# Altium schematic sheet Power.SchDoc(Power) (continued)

## Library data of the parts
C1 C7 = C0603C104K5RACAUTO: Multilayer Ceramic Capacitors MLCC - SMD/SMT 50V 0.1uF X7R 0603 10% AEC-Q200; Manufacturer: Yageo Group; Dissipation Factor: 2.5%; Temperature Coefficient: 30ppm/°C; Value: 100nF; Case Code (Imperial): 0603; Case Code (Metric): 1608; Case/Package: 0603; Category: Ceramic Capacitors; China RoHS: Compliant; Component Kind: Standard; ComponentLink1Description: https://datasheet.octopart.com:443/C0603C104K5RACAUTO-KEMET-datasheet-178087915.pdf?src-supplier=Farnell; ComponentLink1URL: https://datasheet.octopart.com:443/C0603C104K5RACAUTO-KEMET-datasheet-178087915.pdf?src-supplier=Farnell; ComponentLink2Description: https://datasheet.octopart.com:443/C0603C104K5RACAUTO-KEMET-datasheet-140480346.pdf?src-supplier=Farnell; ComponentLink2URL: https://datasheet.octopart.com:443/C0603C104K5RACAUTO-KEMET-datasheet-140480346.pdf?src-supplier=Farnell; ComponentLink3Description: https://datasheet.octopart.com:443/C0603C104K5RACAUTO-KEMET-datasheet-138896278.pdf?src-supplier=Farnell; ComponentLink3URL: https://datasheet.octopart.com:443/C0603C104K5RACAUTO-KEMET-datasheet-138896278.pdf?src-supplier=Farnell; ComponentLink4Description: https://datasheet.octopart.com:443/C0603C104K5RACAUTO-KEMET-datasheet-101575653.pdf?src-supplier=Farnell; ComponentLink4URL: https://datasheet.octopart.com:443/C0603C104K5RACAUTO-KEMET-datasheet-101575653.pdf?src-supplier=Farnell; ComponentLink5Description: https://datasheet.octopart.com:443/C0603C104K5RACAUTO-KEMET-datasheet-169773475.pdf?src-supplier=Farnell; ComponentLink5URL: https://datasheet.octopart.com:443/C0603C104K5RACAUTO-KEMET-datasheet-169773475.pdf?src-supplier=Farnell; ComponentLink6Description: https://datasheet.octopart.com:443/C0603C104K5RAC-AUTO-Kemet-datasheet-11517047.pdf?src-supplier=Farnell; ComponentLink6URL: https://datasheet.octopart.com:443/C0603C104K5RAC-AUTO-Kemet-datasheet-11517047.pdf?src-supplier=Farnell; ComponentLink7Description: https://datasheet.octopart.com:443/C0603C104K5RACAUTO-KEMET-datasheet-137357764.pdf?src-supplier=Upverter; ComponentLink7URL: https://datasheet.octopart.com:443/C0603C104K5RACAUTO-KEMET-datasheet-137357764.pdf?src-supplier=Upverter; ComponentLink8Description: https://datasheet.octopart.com:443/C0603C104K5RACAUTO-Kemet-datasheet-17277841.pdf?src-supplier=Newark; ComponentLink8URL: https://datasheet.octopart.com:443/C0603C104K5RACAUTO-Kemet-datasheet-17277841.pdf?src-supplier=Newark; ComponentLink9Description: https://datasheet.octopart.com:443/C0603C104K5RAC-AUTO-Kemet-datasheet-10850653.pdf?src-supplier=Newark; ComponentLink9URL: https://datasheet.octopart.com:443/C0603C104K5RAC-AUTO-Kemet-datasheet-10850653.pdf?src-supplier=Newark; ComponentLink10Description: https://datasheet.octopart.com:443/C0603C104K5RACAUTO-KEMET-datasheet-176289396.pdf?src-supplier=JRH+Electronics; ComponentLink10URL: https://datasheet.octopart.com:443/C0603C104K5RACAUTO-KEMET-datasheet-176289396.pdf?src-supplier=JRH+Electronics; ComponentLink11Description: https://datasheet.octopart.com:443/C0603C104K5RACAUTO-KEMET-datasheet-153410967.pdf?src-supplier=Electro+Sonic; ComponentLink11URL: https://datasheet.octopart.com:443/C0603C104K5RACAUTO-KEMET-datasheet-153410967.pdf?src-supplier=Electro+Sonic; ComponentLink12Description: https://datasheet.octopart.com:443/C0603C104K5RACAUTO-KEMET-datasheet-142166320.pdf?src-supplier=Electro+Sonic; ComponentLink12URL: https://datasheet.octopart.com:443/C0603C104K5RACAUTO-KEMET-datasheet-142166320.pdf?src-supplier=Electro+Sonic; ComponentLink13Description: https://datasheet.octopart.com:443/C0603C104K5RACAUTO-Kemet-datasheet-66076775.pdf?src-supplier=element14+APAC; ComponentLink13URL: https://datasheet.octopart.com:443/C0603C104K5RACAUTO-Kemet-datasheet-66076775.pdf?src-supplier=element14+APAC; ComponentLink14Description: https://datasheet.octopart.com:443/C0603C104K5RACAUTO-Kemet-datasheet-66204749.pdf?src-supplier=element14+APAC; ComponentLink14URL: https://datasheet.octopart.com:443/C0603C104K5RACAUTO-Kemet-datasheet-66204749.pdf?src-supplier=element14+APAC; ComponentLink15Description: https://datasheet.octopart.com:443/C0603C104K5RACAUTO-Kemet-datasheet-66534724.pdf?src-supplier=element14+APAC; ComponentLink15URL: https://datasheet.octopart.com:443/C0603C104K5RACAUTO-Kemet-datasheet-66534724.pdf?src-supplier=element14+APAC; ComponentLink16Description: https://datasheet.octopart.com:443/C0603C104K5RACAUTO-KEMET-datasheet-83968784.pdf?src-supplier=TME; ComponentLink16URL: https://datasheet.octopart.com:443/C0603C104K5RACAUTO-KEMET-datasheet-83968784.pdf?src-supplier=TME; ComponentLink17Description: https://datasheet.octopart.com:443/C0603C104K5RACAUTO-KEMET-datasheet-83186888.pdf?src-supplier=TME; ComponentLink17URL: https://datasheet.octopart.com:443/C0603C104K5RACAUTO-KEMET-datasheet-83186888.pdf?src-supplier=TME; ComponentLink18Description: https://datasheet.octopart.com/C0603C104K5RACAUTO-KEMET-datasheet-84169754.pdf; ComponentLink18URL: https://datasheet.octopart.com/C0603C104K5RACAUTO-KEMET-datasheet-84169754.pdf; ComponentLink19Description: https://datasheet.octopart.com:443/C0603C104K5RACAUTO-KEMET-datasheet-189767472.pdf?src-supplier=ODG+(Origin+Data+Global); ComponentLink19URL: https://datasheet.octopart.com:443/C0603C104K5RACAUTO-KEMET-datasheet-189767472.pdf?src-supplier=ODG+(Origin+Data+Global); ComponentLink20Description: http://sigma.octopart.com:80/conflict_mineral_statement/Yageo-company-3.pdf?src-supplier=Compliance+Statements; ComponentLink20URL: http://sigma.octopart.com:80/conflict_mineral_statement/Yageo-company-3.pdf?src-supplier=Compliance+Statements; ComponentLink21Description: http://sigma.octopart.com:80/rohs_statement/Yageo-company-2.pdf?src-supplier=Compliance+Statements; ComponentLink21URL: http://sigma.octopart.com:80/rohs_statement/Yageo-company-2.pdf?src-supplier=Compliance+Statements; Contact Plating: Tin; Country of Origin: Mexico; Depth: 800µm; Dielectric: X7R; Dielectric Material: Ceramic; Export Control Classification Number (ECCN) Code: EAR99; Harmonized Tariff Schedule (HTS) Code: 8532.24.00.20; Height: 800µm; Introduction Date: 2011-07-27; Length: 1.6mm; Lifecycle Status: Production; Manufacturer Part Number: C0603C104K5RACAUTO; Material: Ceramic; Max Operating Temperature: 125°C; Min Operating Temperature: -55°C; Mounting Technology: Surface Mount; Number of Terminals: 2; Packaging: Cut Tape; Pricing: 1=0.15, 10=0.083, 50=0.0574, 100=0.0497, 500=0.0367 (USD); Radiation Hardening: No; Ratings: AEC-Q200; REACH SVHC: Yes; RoHS: Compliant; Schedule B: 8532240020, 8532240020|8532240020, 8532240020|8532240020|8532240020, 8532240020|8532240020|8532240020|8532240020; Stock: 1408607; Supplier: DigiKey; Supplier Part Number: 399-6856-6-ND; Termination: SMD/SMT; Thickness: 863.6µm; Tolerance: 10%; Voltage Rating: 50V; Voltage Rating (DC): 50V; Width: 800µm; Library Name: Tremelo_Pedal.SchLib
C2 = GMC31X7R106K50NT: Ceramic Capacitor, Multilayer, Ceramic, 50V, 10% +Tol, 10% -Tol, X7R, 15% TC, 10uF, Surface Mount, 1206; Manufacturer: Cal-Chip Electronics; Value: 10µF; Case/Package: 1206; Category: Ceramic Capacitors; China RoHS: Compliant; Component Kind: Standard; ComponentLink1Description: https://datasheet.octopart.com:443/GMC31X7R106K50NT-Cal-Chip-Electronics-datasheet-182028815.pdf?src-supplier=IHS; ComponentLink1URL: https://datasheet.octopart.com:443/GMC31X7R106K50NT-Cal-Chip-Electronics-datasheet-182028815.pdf?src-supplier=IHS; ComponentLink2Description: https://datasheet.octopart.com:443/GMC31X7R106K50NT-Cal-Chip-Electronics-datasheet-151836681.pdf?src-supplier=Upverter; ComponentLink2URL: https://datasheet.octopart.com:443/GMC31X7R106K50NT-Cal-Chip-Electronics-datasheet-151836681.pdf?src-supplier=Upverter; ComponentLink3Description: https://sigma.octopart.com:443/151836682/technical_drawing/Cal-Chip-Electronics-GMC31X7R106K50NT.pdf?src-supplier=Upverter; ComponentLink3URL: https://sigma.octopart.com:443/151836682/technical_drawing/Cal-Chip-Electronics-GMC31X7R106K50NT.pdf?src-supplier=Upverter; Country of Origin: South Korea; Dielectric Material: Ceramic; Export Control Classification Number (ECCN) Code: EAR99; Harmonized Tariff Schedule (HTS) Code: 8532.24.00.20; Height: 1.9mm; Introduction Date: 2020-12-03; Length: 3.2mm; Lifecycle Status: Production; Manufacturer Part Number: GMC31X7R106K50NT; Material: Ceramic; Max Operating Temperature: 125°C; Min Operating Temperature: -55°C; Mounting Technology: Surface Mount; Pricing: 1=1.26, 10=0.815, 50=0.64, 100=0.5858, 500=0.49438, 2000=0.44281, 6000=0.41404 (USD); REACH SVHC: Unaffected; RoHS: Compliant; Stock: 112299; Supplier: DigiKey; Supplier Part Number: 4713-GMC31X7R106K50NTCT-ND; Tolerance: 10%; Voltage Rating: 50V; Voltage Rating (DC): 50V; Width: 1.6mm; Library Name: Tremelo_Pedal.SchLib
C3 C8 = T491X107K025AT: 100UF Solid MNO2 Tantalum Electrolytic Capacitor, 25 V DC +/-10%, T491 Series; Manufacturer: Yageo Group; Dissipation Factor: 8%; ESR (Equivalent Series Resistance): 300mΩ; Leakage Current: 25µA; Life (Hours): 2000hours; Polarity: Polar; Ripple Current: 296.8mA; Tolerance: 10%; Value: 100µF; Voltage: 25V; Case Code (Imperial): 2917; Case Code (Metric): 7343; Case/Package: 2917; Category: Tantalum Capacitors; China RoHS: Compliant; Component Kind: Standard; ComponentLink1Description: https://datasheet.octopart.com:443/T491X107K025AT-KEMET-datasheet-182059868.pdf?src-supplier=IHS; ComponentLink1URL: https://datasheet.octopart.com:443/T491X107K025AT-KEMET-datasheet-182059868.pdf?src-supplier=IHS; ComponentLink2Description: https://datasheet.octopart.com:443/T491X107K025AT-KEMET-datasheet-84169765.pdf?src-supplier=Newark; ComponentLink2URL: https://datasheet.octopart.com:443/T491X107K025AT-KEMET-datasheet-84169765.pdf?src-supplier=Newark; ComponentLink3Description: https://datasheet.octopart.com:443/T491X107K025AT-KEMET-datasheet-136608597.pdf?src-supplier=Newark; ComponentLink3URL: https://datasheet.octopart.com:443/T491X107K025AT-KEMET-datasheet-136608597.pdf?src-supplier=Newark; ComponentLink4Description: https://datasheet.octopart.com:443/T491X107K025AT-KEMET-datasheet-176142809.pdf?src-supplier=Newark; ComponentLink4URL: https://datasheet.octopart.com:443/T491X107K025AT-KEMET-datasheet-176142809.pdf?src-supplier=Newark; ComponentLink5Description: https://datasheet.octopart.com:443/T491X107K025AT-Kemet-datasheet-13318819.pdf?src-supplier=Newark; ComponentLink5URL: https://datasheet.octopart.com:443/T491X107K025AT-Kemet-datasheet-13318819.pdf?src-supplier=Newark; ComponentLink6Description: https://datasheet.octopart.com:443/T491X107K025AT-Kemet-datasheet-12969819.pdf?src-supplier=Newark; ComponentLink6URL: https://datasheet.octopart.com:443/T491X107K025AT-Kemet-datasheet-12969819.pdf?src-supplier=Newark; ComponentLink7Description: https://datasheet.octopart.com:443/T491X107K025AT-Kemet-datasheet-10674785.pdf?src-supplier=Newark; ComponentLink7URL: https://datasheet.octopart.com:443/T491X107K025AT-Kemet-datasheet-10674785.pdf?src-supplier=Newark; ComponentLink8Description: https://datasheet.octopart.com:443/T491X107K025AT-KEMET-datasheet-149529478.pdf?src-supplier=Farnell; ComponentLink8URL: https://datasheet.octopart.com:443/T491X107K025AT-KEMET-datasheet-149529478.pdf?src-supplier=Farnell; ComponentLink9Description: https://datasheet.octopart.com:443/T491X107K025AT-KEMET-datasheet-181973138.pdf?src-supplier=Farnell; ComponentLink9URL: https://datasheet.octopart.com:443/T491X107K025AT-KEMET-datasheet-181973138.pdf?src-supplier=Farnell; ComponentLink10Description: https://datasheet.octopart.com:443/T491X107K025AT-KEMET-datasheet-101578681.pdf?src-supplier=Farnell; ComponentLink10URL: https://datasheet.octopart.com:443/T491X107K025AT-KEMET-datasheet-101578681.pdf?src-supplier=Farnell; ComponentLink11Description: https://datasheet.octopart.com:443/T491X107K025AT-Kemet-datasheet-26590290.pdf?src-supplier=Farnell; ComponentLink11URL: https://datasheet.octopart.com:443/T491X107K025AT-Kemet-datasheet-26590290.pdf?src-supplier=Farnell; ComponentLink12Description: https://datasheet.octopart.com:443/T491X107K025AT-Kemet-datasheet-26590412.pdf?src-supplier=Farnell; ComponentLink12URL: https://datasheet.octopart.com:443/T491X107K025AT-Kemet-datasheet-26590412.pdf?src-supplier=Farnell; ComponentLink13Description: https://datasheet.octopart.com:443/T491X107K025AT-Kemet-datasheet-32026213.pdf?src-supplier=Farnell; ComponentLink13URL: https://datasheet.octopart.com:443/T491X107K025AT-Kemet-datasheet-32026213.pdf?src-supplier=Farnell; ComponentLink14Description: https://datasheet.octopart.com:443/T491X107K025AT-Kemet-datasheet-15068589.pdf?src-supplier=element14+APAC; ComponentLink14URL: https://datasheet.octopart.com:443/T491X107K025AT-Kemet-datasheet-15068589.pdf?src-supplier=element14+APAC; ComponentLink15Description: https://datasheet.octopart.com:443/T491X107K025AT-KEMET-datasheet-38887703.pdf?src-supplier=Electro+Sonic; ComponentLink15URL: https://datasheet.octopart.com:443/T491X107K025AT-KEMET-datasheet-38887703.pdf?src-supplier=Electro+Sonic; ComponentLink16Description: https://datasheet.octopart.com:443/T491X107K025AT-KEMET-datasheet-142660448.pdf?src-supplier=TME; ComponentLink16URL: https://datasheet.octopart.com:443/T491X107K025AT-KEMET-datasheet-142660448.pdf?src-supplier=TME; ComponentLink17Description: https://datasheet.octopart.com:443/T491X107K025AT-Kemet-datasheet-67498910.pdf?src-supplier=TME; ComponentLink17URL: https://datasheet.octopart.com:443/T491X107K025AT-Kemet-datasheet-67498910.pdf?src-supplier=TME; ComponentLink18Description: https://datasheet.octopart.com:443/T491X107K025AT-KEMET-datasheet-117635134.pdf?src-supplier=TME; ComponentLink18URL: https://datasheet.octopart.com:443/T491X107K025AT-KEMET-datasheet-117635134.pdf?src-supplier=TME; ComponentLink19Description: https://datasheet.octopart.com:443/T491X107K025AT-Kemet-datasheet-62346238.pdf?src-supplier=TME; ComponentLink19URL: https://datasheet.octopart.com:443/T491X107K025AT-Kemet-datasheet-62346238.pdf?src-supplier=TME; ComponentLink20Description: https://datasheet.octopart.com:443/T491X107K025AT-Kemet-datasheet-49872651.pdf?src-supplier=TME; ComponentLink20URL: https://datasheet.octopart.com:443/T491X107K025AT-Kemet-datasheet-49872651.pdf?src-supplier=TME; ComponentLink21Description: https://datasheet.octopart.com:443/T491X107K025AT-KEMET-datasheet-164857296.pdf?src-supplier=Burklin+Elektronik; ComponentLink21URL: https://datasheet.octopart.com:443/T491X107K025AT-KEMET-datasheet-164857296.pdf?src-supplier=Burklin+Elektronik; ComponentLink22Description: https://datasheet.octopart.com:443/T491X107K025AT-Kemet-datasheet-62307693.pdf?src-supplier=RS+(Formerly+Allied+Electronics); ComponentLink22URL: https://datasheet.octopart.com:443/T491X107K025AT-Kemet-datasheet-62307693.pdf?src-supplier=RS+(Formerly+Allied+Electronics); ComponentLink23Description: https://datasheet.octopart.com:443/T491X107K025AT-Kemet-datasheet-14047436.pdf?src-supplier=iiiC; ComponentLink23URL: https://datasheet.octopart.com:443/T491X107K025AT-Kemet-datasheet-14047436.pdf?src-supplier=iiiC; ComponentLink24Description: http://sigma.octopart.com:80/conflict_mineral_statement/Yageo-company-3.pdf?src-supplier=Compliance+Statements; ComponentLink24URL: http://sigma.octopart.com:80/conflict_mineral_statement/Yageo-company-3.pdf?src-supplier=Compliance+Statements; ComponentLink25Description: http://sigma.octopart.com:80/rohs_statement/Yageo-company-2.pdf?src-supplier=Compliance+Statements; ComponentLink25URL: http://sigma.octopart.com:80/rohs_statement/Yageo-company-2.pdf?src-supplier=Compliance+Statements; Country of Origin: Mexico; Depth: 4.3mm; Dielectric Material: Tantalum; Export Control Classification Number (ECCN) Code: EAR99; Failure Rate: 0.001%; Features: General Purpose; Harmonized Tariff Schedule (HTS) Code: 8532.21.00.50; Height: 4mm; Height - Seated (Max): 4.2926mm; Introduction Date: 2007-10-05; Lead Free: Lead Free; Length: 7.3mm; Lifecycle Status: Production; Manufacturer Part Number: T491X107K025AT; Max Operating Temperature: 125°C; Min Operating Temperature: -55°C; Mounting Technology: Surface Mount; Number of Terminals: 2; Pins: 2; Pricing: 1=3.86, 10=2.731, 50=2.2814, 100=2.1423 (USD); Radiation Hardening: No; REACH SVHC: Unaffected; RoHS: Compliant; Schedule B: 8532210050, 8532210050|8532210050|8532210050|8532210050|8532210050|8532210050|8532210050|8532210050|8532210050|8532210050|8532210050|8532210050|8532210050|8532210050|8532210050|8532210050|8532210050|8532210050|8532210050|8532210050|8532210050|8532210050|8532210050|85; Stock: 121026; Supplier: DigiKey; Supplier Part Number: 399-8410-6-ND; Terminal Pitch: 1.3mm; Termination: SMD/SMT; Voltage Rating: 25V; Voltage Rating (DC): 25V; Width: 4.3mm; Library Name: Tremelo_Pedal.SchLib
C4 = 600F100JT250XT4K: Ceramic Capacitor, Multilayer, Ceramic, 250V, 5% +Tol, 5% -Tol, C0G, 30ppm/Cel TC, 0.00001uF, Surface Mount, 0805; Manufacturer: KYOCERA AVX; Value: 10pF; Case/Package: 0805; Category: Ceramic Capacitors; Component Kind: Standard; ComponentLink1Description: https://datasheet.octopart.com:443/600F100JT250XT4K-KYOCERA-AVX-datasheet-182064039.pdf?src-supplier=IHS; ComponentLink1URL: https://datasheet.octopart.com:443/600F100JT250XT4K-KYOCERA-AVX-datasheet-182064039.pdf?src-supplier=IHS; ComponentLink2Description: http://sigma.octopart.com:80/conflict_mineral_statement/AVX-company-34.pdf?src-supplier=Compliance+Statements; ComponentLink2URL: http://sigma.octopart.com:80/conflict_mineral_statement/AVX-company-34.pdf?src-supplier=Compliance+Statements; ComponentLink3Description: http://sigma.octopart.com:80/reach_statement/AVX-company-19.pdf?src-supplier=Compliance+Statements; ComponentLink3URL: http://sigma.octopart.com:80/reach_statement/AVX-company-19.pdf?src-supplier=Compliance+Statements; Dielectric Material: Ceramic; Export Control Classification Number (ECCN) Code: EAR99; Harmonized Tariff Schedule (HTS) Code: 8532.24.00.20; Height: 1.3mm; Introduction Date: 2021-07-06; Length: 2.01mm; Lifecycle Status: Production; LTB Date: 2022-01-31; Manufacturer Part Number: 600F100JT250XT4K; Max Operating Temperature: 125°C; Min Operating Temperature: -55°C; Mounting Technology: Surface Mount; Number of Terminals: 2; Pricing: 1=3.02, 10=2.055, 50=1.6728, 100=1.5547, 500=1.35506 (USD); REACH SVHC: Yes; RoHS: Compliant; Stock: 21131; Supplier: DigiKey; Supplier Part Number: 478-600F100JT250XT4KCT-ND; Tolerance: 5%; Voltage Rating (DC): 250V; Width: 1.24mm; Library Name: Tremelo_Pedal.SchLib
C5 = 50ZLH100MEFC8X11.5: Radial Can ALUMINUM ELECTROLYTIC CAPACITOR ZLH 50V 100muF ±20% 0.315Dia 8.00mm; Manufacturer: Rubycon; Dielectric Material: Aluminium; Impedance: 74mΩ; Lead Diameter: 600µm; Leakage Current: 50µA; Life (Hours): 8000hours; Polarity: Polar; Ripple Current: 362mA; Ripple Current (AC): 724mA; Value: 100µF; Case/Package: Radial; Category: Aluminum Electrolytic Capacitors; Component Kind: Standard; ComponentLink1Description: https://datasheet.octopart.com:443/50ZLH100MEFC8X11.5-Rubycon-datasheet-181960486.pdf?src-supplier=Electro+Sonic; ComponentLink1URL: https://datasheet.octopart.com:443/50ZLH100MEFC8X11.5-Rubycon-datasheet-181960486.pdf?src-supplier=Electro+Sonic; ComponentLink2Description: https://datasheet.octopart.com:443/50ZLH100MEFC8X11.5-Rubycon-datasheet-154841597.pdf?src-supplier=JRH+Electronics; ComponentLink2URL: https://datasheet.octopart.com:443/50ZLH100MEFC8X11.5-Rubycon-datasheet-154841597.pdf?src-supplier=JRH+Electronics; ComponentLink3Description: https://datasheet.octopart.com:443/50ZLH100MEFC8X11.5-Rubycon-datasheet-86451566.pdf?src-supplier=Farnell; ComponentLink3URL: https://datasheet.octopart.com:443/50ZLH100MEFC8X11.5-Rubycon-datasheet-86451566.pdf?src-supplier=Farnell; ComponentLink4Description: https://datasheet.octopart.com:443/50ZLH100MEFC8X11.5-Rubycon-datasheet-21133432.pdf?src-supplier=Newark; ComponentLink4URL: https://datasheet.octopart.com:443/50ZLH100MEFC8X11.5-Rubycon-datasheet-21133432.pdf?src-supplier=Newark; ComponentLink5Description: https://datasheet.octopart.com:443/50ZLH100MEFC8X11.5-Rubycon-datasheet-12510202.pdf?src-supplier=_legacy+Avnet; ComponentLink5URL: https://datasheet.octopart.com:443/50ZLH100MEFC8X11.5-Rubycon-datasheet-12510202.pdf?src-supplier=_legacy+Avnet; Country of Origin: Japan; Diameter: 8mm; Export Control Classification Number (ECCN) Code: EAR99; Harmonized Tariff Schedule (HTS) Code: 8532.22.00.20; Height: 11.5mm; Height - Seated (Max): 13.0048mm; Introduction Date: 2010-09-20; Lead Pitch: 3.5mm; Length: 11.5mm; Lifecycle Status: Production; Manufacturer Part Number: 50ZLH100MEFC8X11.5; Max Operating Temperature: 105°C; Min Operating Temperature: -40°C; Mounting Technology: Through Hole; Number of Terminals: 2; Packaging: Bulk; Pins: 2; Pricing: 1=0.45, 10=0.275, 50=0.2022, 100=0.1791, 500=0.139, 2000=0.11581, 4000=0.10704, 6000=0.10262 (USD); Radiation Hardening: No; REACH SVHC: Yes; RoHS: Compliant; Schedule B: 8532220020, 8532220020|8532220020|8532220020|8532220020|8532220020; Stock: 23827; Supplier: DigiKey; Supplier Part Number: 1189-2327-ND; Terminal Pitch: 3.5mm; Termination: Radial; Tolerance: 20%; Voltage: 50V; Voltage Rating: 50V; Voltage Rating (DC): 50V; Library Name: EcoCar_Accessory_Controller_V2.IntLib
C6 = C3225X5R1H106K250AB: 50V 10uF X5R 卤10% 1210 Multilayer Ceramic Capacitors MLCC - SMD/SMT ROHS; Manufacturer: TDK; Manufacturer Lifecycle Status: PRODUCTION; Manufacturer Package Identifier: 1210 (3225 Metric); Tolerance: 10%; Value: 10µF; Case Code (Imperial): 1210; Case Code (Metric): 3225; Case/Package: 1210; Category: Ceramic Capacitors; China RoHS: Compliant; Component Kind: Standard; ComponentLink1Description: https://datasheet.octopart.com:443/C3225X5R1H106K250AB-TDK-datasheet-175423548.pdf?src-supplier=TME; ComponentLink1URL: https://datasheet.octopart.com:443/C3225X5R1H106K250AB-TDK-datasheet-175423548.pdf?src-supplier=TME; ComponentLink2Description: https://datasheet.octopart.com:443/C3225X5R1H106K250AB-TDK-datasheet-182135025.pdf?src-supplier=TME; ComponentLink2URL: https://datasheet.octopart.com:443/C3225X5R1H106K250AB-TDK-datasheet-182135025.pdf?src-supplier=TME; ComponentLink3Description: https://datasheet.octopart.com:443/C3225X5R1H106K250AB-TDK-datasheet-159617022.pdf?src-supplier=TME; ComponentLink3URL: https://datasheet.octopart.com:443/C3225X5R1H106K250AB-TDK-datasheet-159617022.pdf?src-supplier=TME; ComponentLink4Description: https://sigma.octopart.com:443/138898468/technical_drawing/TDK-C3225X5R1H106K250AB.pdf?src-supplier=Upverter; ComponentLink4URL: https://sigma.octopart.com:443/138898468/technical_drawing/TDK-C3225X5R1H106K250AB.pdf?src-supplier=Upverter; ComponentLink5Description: https://datasheet.octopart.com:443/C3225X5R1H106K250AB-TDK-datasheet-151546523.pdf?src-supplier=ODG+(Origin+Data+Global); ComponentLink5URL: https://datasheet.octopart.com:443/C3225X5R1H106K250AB-TDK-datasheet-151546523.pdf?src-supplier=ODG+(Origin+Data+Global); ComponentLink6Description: https://datasheet.octopart.com:443/C3225X5R1H106K250AB-TDK-datasheet-82475248.pdf?src-supplier=Farnell; ComponentLink6URL: https://datasheet.octopart.com:443/C3225X5R1H106K250AB-TDK-datasheet-82475248.pdf?src-supplier=Farnell; ComponentLink7Description: https://datasheet.octopart.com/C3225X5R1H106K250AB-TDK-datasheet-41194876.pdf; ComponentLink7URL: https://datasheet.octopart.com/C3225X5R1H106K250AB-TDK-datasheet-41194876.pdf; ComponentLink8Description: https://datasheet.octopart.com:443/C3225X5R1H106K250AB-TDK-datasheet-25352837.pdf?src-supplier=Future+Electronics; ComponentLink8URL: https://datasheet.octopart.com:443/C3225X5R1H106K250AB-TDK-datasheet-25352837.pdf?src-supplier=Future+Electronics; ComponentLink9Description: https://datasheet.octopart.com:443/C3225X5R1H106K250AB-TDK-datasheet-23766614.pdf?src-supplier=Future+Electronics; ComponentLink9URL: https://datasheet.octopart.com:443/C3225X5R1H106K250AB-TDK-datasheet-23766614.pdf?src-supplier=Future+Electronics; ComponentLink10Description: https://datasheet.octopart.com:443/C3225X5R1H106K250AB-TDK-datasheet-10921857.pdf?src-supplier=Newark; ComponentLink10URL: https://datasheet.octopart.com:443/C3225X5R1H106K250AB-TDK-datasheet-10921857.pdf?src-supplier=Newark; Composition: Ceramic; Depth: 2.5mm; Dielectric: X5R; Dielectric Material: Ceramic; Height: 2.5mm; Lead Free: Lead Free; Length: 3.2mm; Lifecycle Status: Production; Manufacturer Part Number: C3225X5R1H106K250AB; Material: Ceramic; Max Length: 3.6mm; Max Operating Temperature: 85°C; Max Width: 2.8mm; Min Length: 2.8mm; Min Operating Temperature: -55°C; Min Width: 2.2mm; Mounting Technology: Surface Mount; Number of Terminals: 2; Packaging: Digi-Reel®; Pricing: 1=1.05, 10=0.673, 50=0.5232, 100=0.4769, 500=0.39878 (USD); REACH SVHC: Unaffected; RoHS: Compliant; Schedule B: 8532240020, 8532240020|8532240020|8532240020|8532240020|8532240020; Stock: 40535; Supplier: DigiKey; Supplier Part Number: 445-9074-1-ND; Termination: SMD/SMT; Voltage Rating: 50V; Voltage Rating (DC): 50V; Weight: 15.989131mg; Width: 2.5mm; Library Name: Tremelo_Pedal.SchLib
C9 C10 = GRM31CZ72A475KE11L: 100V 4.7uF X7R ±10% 1206 Multilayer Ceramic Capacitors MLCC - SMD/SMT ROHS; Manufacturer: Murata; Manufacturer Lifecycle Status: IN PRODUCTION; Value: 4.7µF; Case/Package: 1206; Category: Ceramic Capacitors; Component Kind: Standard; ComponentLink1Description: https://datasheet.octopart.com:443/GRM31CZ72A475KE11L-Murata-datasheet-141280657.pdf?src-supplier=Geoflip; ComponentLink1URL: https://datasheet.octopart.com:443/GRM31CZ72A475KE11L-Murata-datasheet-141280657.pdf?src-supplier=Geoflip; ComponentLink2Description: https://datasheet.octopart.com:443/GRM31CZ72A475KE11L-Murata-datasheet-140709174.pdf?src-supplier=Future+Electronics; ComponentLink2URL: https://datasheet.octopart.com:443/GRM31CZ72A475KE11L-Murata-datasheet-140709174.pdf?src-supplier=Future+Electronics; ComponentLink3Description: https://datasheet.octopart.com:443/GRM31CZ72A475KE11L-Murata-datasheet-165579666.pdf?src-supplier=TME; ComponentLink3URL: https://datasheet.octopart.com:443/GRM31CZ72A475KE11L-Murata-datasheet-165579666.pdf?src-supplier=TME; ComponentLink4Description: https://datasheet.octopart.com:443/GRM31CZ72A475KE11L-Murata-datasheet-138746415.pdf?src-supplier=Ciiva; ComponentLink4URL: https://datasheet.octopart.com:443/GRM31CZ72A475KE11L-Murata-datasheet-138746415.pdf?src-supplier=Ciiva; ComponentLink5Description: http://sigma.octopart.com:80/reach_statement/Murata-company-16.pdf?src-supplier=Compliance+Statements; ComponentLink5URL: http://sigma.octopart.com:80/reach_statement/Murata-company-16.pdf?src-supplier=Compliance+Statements; Dielectric Material: Ceramic; Export Control Classification Number (ECCN) Code: EAR99; Harmonized Tariff Schedule (HTS) Code: 8532.24.00.20; Height: 1.6mm; Introduction Date: 2017-02-22; Length: 3.2mm; Lifecycle Status: Production; Manufacturer Part Number: GRM31CZ72A475KE11L; Material: Ceramic; Max Operating Temperature: 125°C; Min Operating Temperature: -55°C; Mounting Technology: Surface Mount; Number of Terminals: 2; Pricing: 1=0.79, 10=0.497, 50=0.382, 100=0.3466, 500=0.28682, 1000=0.26833 (USD); REACH SVHC: Yes; RoHS: Compliant; Stock: 27781; Supplier: DigiKey; Supplier Part Number: 490-GRM31CZ72A475KE11LCT-ND; Thickness: 1.6mm; Tolerance: 10%; Voltage Rating: 100V; Voltage Rating (DC): 100V; Width: 1.6mm; Library Name: EcoCar_Accessory_Controller_V2.IntLib
C11 = C3216X7R2A334K130AA: 100V 330nF X7R 卤10% 1206 Multilayer Ceramic Capacitors MLCC - SMD/SMT ROHS; Manufacturer: TDK; Manufacturer Lifecycle Status: PRODUCTION; Tolerance: 10%; Case Code (Imperial): 1206; Case Code (Metric): 3216; Case/Package: 1206; Category: Ceramic Capacitors; China RoHS: Compliant; Component Kind: Standard; ComponentLink1Description: https://datasheet.octopart.com:443/C3216X7R2A334K130AA-TDK-datasheet-159617022.pdf?src-supplier=Newark; ComponentLink1URL: https://datasheet.octopart.com:443/C3216X7R2A334K130AA-TDK-datasheet-159617022.pdf?src-supplier=Newark; ComponentLink2Description: https://datasheet.octopart.com:443/C3216X7R2A334K130AA-TDK-datasheet-41194876.pdf?src-supplier=Newark; ComponentLink2URL: https://datasheet.octopart.com:443/C3216X7R2A334K130AA-TDK-datasheet-41194876.pdf?src-supplier=Newark; ComponentLink3Description: https://datasheet.octopart.com:443/C3216X7R2A334K130AA-TDK-datasheet-43914167.pdf?src-supplier=Newark; ComponentLink3URL: https://datasheet.octopart.com:443/C3216X7R2A334K130AA-TDK-datasheet-43914167.pdf?src-supplier=Newark; ComponentLink4Description: https://datasheet.octopart.com:443/C3216X7R2A334K130AA-TDK-datasheet-13376850.pdf?src-supplier=Newark; ComponentLink4URL: https://datasheet.octopart.com:443/C3216X7R2A334K130AA-TDK-datasheet-13376850.pdf?src-supplier=Newark; ComponentLink5Description: https://datasheet.octopart.com:443/C3216X7R2A334K130AA-TDK-datasheet-10497308.pdf?src-supplier=Newark; ComponentLink5URL: https://datasheet.octopart.com:443/C3216X7R2A334K130AA-TDK-datasheet-10497308.pdf?src-supplier=Newark; ComponentLink6Description: https://datasheet.octopart.com:443/C3216X7R2A334K130AA-TDK-datasheet-151546522.pdf?src-supplier=TDK; ComponentLink6URL: https://datasheet.octopart.com:443/C3216X7R2A334K130AA-TDK-datasheet-151546522.pdf?src-supplier=TDK; ComponentLink7Description: https://datasheet.octopart.com:443/C3216X7R2A334K130AA-TDK-datasheet-154543791.pdf?src-supplier=ODG+(Origin+Data+Global); ComponentLink7URL: https://datasheet.octopart.com:443/C3216X7R2A334K130AA-TDK-datasheet-154543791.pdf?src-supplier=ODG+(Origin+Data+Global); ComponentLink8Description: https://datasheet.octopart.com:443/C3216X7R2A334K130AA-TDK-datasheet-25352837.pdf?src-supplier=Future+Electronics; ComponentLink8URL: https://datasheet.octopart.com:443/C3216X7R2A334K130AA-TDK-datasheet-25352837.pdf?src-supplier=Future+Electronics; ComponentLink9Description: https://datasheet.octopart.com:443/C3216X7R2A334K130AA-TDK-datasheet-23766614.pdf?src-supplier=Future+Electronics; ComponentLink9URL: https://datasheet.octopart.com:443/C3216X7R2A334K130AA-TDK-datasheet-23766614.pdf?src-supplier=Future+Electronics; Composition: Ceramic; Depth: 1.6mm; Dielectric: X7R; Dielectric Material: Ceramic; Height: 1.3mm; Lead Free: Lead Free; Length: 3.2mm; Lifecycle Status: Production; Manufacturer Part Number: C3216X7R2A334K130AA; Material: Ceramic; Max Length: 3.4mm; Max Operating Temperature: 125°C; Max Width: 1.8mm; Min Length: 3mm; Min Operating Temperature: -55°C; Min Width: 1.4mm; Mounting Technology: Surface Mount; Number of Terminals: 2; Pins: 2; Pricing: 1=0.47, 10=0.285, 50=0.211, 100=0.1883, 500=0.15002 (USD); REACH SVHC: Unaffected; RoHS: Compliant; Schedule B: 8532240020, 8532240020|8532240020|8532240020|8532240020|8532240020; Stock: 259473; Supplier: DigiKey; Supplier Part Number: 445-4464-1-ND; Termination: SMD/SMT; Thickness: 1.4478mm; Value: 330nF; Voltage: 100V; Voltage Rating: 100V; Voltage Rating (DC): 100V; Weight: 16.187578mg; Width: 1.6mm; Library Name: Tremelo_Pedal.SchLib
C12 = C1206C104K5RACTU: Multilayer Ceramic Capacitors MLCC – SMD/SMT; KEMET; C1206C104K5RACTU; 100 nF; 1206; 50 V; 50 V; Manufacturer: Yageo Group; Dielectric: X7R; Tolerance: 10%; Value: 100nF; Voltage: 50V; Case Code (Imperial): 1206; Case Code (Metric): 3216; Case/Package: 1206; Category: Ceramic Capacitors; China RoHS: Compliant; Component Kind: Standard; ComponentLink1Description: https://datasheet.octopart.com:443/C1206C104K5RACTU-KEMET-datasheet-178445679.pdf?src-supplier=IHS; ComponentLink1URL: https://datasheet.octopart.com:443/C1206C104K5RACTU-KEMET-datasheet-178445679.pdf?src-supplier=IHS; ComponentLink2Description: https://datasheet.octopart.com:443/C1206C104K5RAC7800-KEMET-datasheet-182044529.pdf?src-supplier=IHS; ComponentLink2URL: https://datasheet.octopart.com:443/C1206C104K5RAC7800-KEMET-datasheet-182044529.pdf?src-supplier=IHS; ComponentLink3Description: https://datasheet.octopart.com:443/C1206C104K5RACTU-KEMET-datasheet-137357759.pdf?src-supplier=Farnell; ComponentLink3URL: https://datasheet.octopart.com:443/C1206C104K5RACTU-KEMET-datasheet-137357759.pdf?src-supplier=Farnell; ComponentLink4Description: https://datasheet.octopart.com:443/C1206C104K5RACTU-KEMET-datasheet-101576564.pdf?src-supplier=Farnell; ComponentLink4URL: https://datasheet.octopart.com:443/C1206C104K5RACTU-KEMET-datasheet-101576564.pdf?src-supplier=Farnell; ComponentLink5Description: https://datasheet.octopart.com:443/C1206C104K5RACTU-KEMET-datasheet-176142416.pdf?src-supplier=Farnell; ComponentLink5URL: https://datasheet.octopart.com:443/C1206C104K5RACTU-KEMET-datasheet-176142416.pdf?src-supplier=Farnell; ComponentLink6Description: https://datasheet.octopart.com:443/C1206C104K5RACTU-Kemet-datasheet-14356739.pdf?src-supplier=Farnell; ComponentLink6URL: https://datasheet.octopart.com:443/C1206C104K5RACTU-Kemet-datasheet-14356739.pdf?src-supplier=Farnell; ComponentLink7Description: https://datasheet.octopart.com:443/C1206C104K5RAC-TU-Kemet-datasheet-7833651.pdf?src-supplier=Farnell; ComponentLink7URL: https://datasheet.octopart.com:443/C1206C104K5RAC-TU-Kemet-datasheet-7833651.pdf?src-supplier=Farnell; ComponentLink8Description: https://datasheet.octopart.com:443/C1206C104K5RAC-TU-Kemet-datasheet-7601896.pdf?src-supplier=Farnell; ComponentLink8URL: https://datasheet.octopart.com:443/C1206C104K5RAC-TU-Kemet-datasheet-7601896.pdf?src-supplier=Farnell; ComponentLink9Description: https://datasheet.octopart.com:443/C1206C104K5RAC-TU-Kemet-datasheet-5316294.pdf?src-supplier=Farnell; ComponentLink9URL: https://datasheet.octopart.com:443/C1206C104K5RAC-TU-Kemet-datasheet-5316294.pdf?src-supplier=Farnell; ComponentLink10Description: https://datasheet.octopart.com:443/C1206C104K5RAC-TU-Kemet-datasheet-5314837.pdf?src-supplier=Farnell; ComponentLink10URL: https://datasheet.octopart.com:443/C1206C104K5RAC-TU-Kemet-datasheet-5314837.pdf?src-supplier=Farnell; ComponentLink11Description: https://datasheet.octopart.com:443/C1206C104K5RAC-TU-Kemet-datasheet-8092359.pdf?src-supplier=Farnell; ComponentLink11URL: https://datasheet.octopart.com:443/C1206C104K5RAC-TU-Kemet-datasheet-8092359.pdf?src-supplier=Farnell; ComponentLink12Description: https://datasheet.octopart.com:443/C1206C104K5RAC-TU-Kemet-datasheet-5314449.pdf?src-supplier=Farnell; ComponentLink12URL: https://datasheet.octopart.com:443/C1206C104K5RAC-TU-Kemet-datasheet-5314449.pdf?src-supplier=Farnell; ComponentLink13Description: https://datasheet.octopart.com:443/C1206C104K5RAC-TU-Kemet-datasheet-5314969.pdf?src-supplier=Farnell; ComponentLink13URL: https://datasheet.octopart.com:443/C1206C104K5RAC-TU-Kemet-datasheet-5314969.pdf?src-supplier=Farnell; ComponentLink14Description: https://datasheet.octopart.com:443/C1206C104K5RAC-TU-Kemet-datasheet-5314627.pdf?src-supplier=Farnell; ComponentLink14URL: https://datasheet.octopart.com:443/C1206C104K5RAC-TU-Kemet-datasheet-5314627.pdf?src-supplier=Farnell; ComponentLink15Description: https://datasheet.octopart.com:443/C1206C104K5RAC-TU-Kemet-datasheet-5389132.pdf?src-supplier=Farnell; ComponentLink15URL: https://datasheet.octopart.com:443/C1206C104K5RAC-TU-Kemet-datasheet-5389132.pdf?src-supplier=Farnell; ComponentLink16Description: https://datasheet.octopart.com:443/C1206C104K5RAC-TU-Kemet-datasheet-5313836.pdf?src-supplier=Farnell; ComponentLink16URL: https://datasheet.octopart.com:443/C1206C104K5RAC-TU-Kemet-datasheet-5313836.pdf?src-supplier=Farnell; ComponentLink17Description: https://datasheet.octopart.com:443/C1206C104K5RAC-TU-Kemet-datasheet-8111010.pdf?src-supplier=Farnell; ComponentLink17URL: https://datasheet.octopart.com:443/C1206C104K5RAC-TU-Kemet-datasheet-8111010.pdf?src-supplier=Farnell; ComponentLink18Description: https://datasheet.octopart.com:443/C1206C104K5RAC-TU-Kemet-datasheet-7627733.pdf?src-supplier=Farnell; ComponentLink18URL: https://datasheet.octopart.com:443/C1206C104K5RAC-TU-Kemet-datasheet-7627733.pdf?src-supplier=Farnell; ComponentLink19Description: https://datasheet.octopart.com:443/C1206C104K5RACTU-Kemet-datasheet-500041.pdf?src-supplier=Farnell; ComponentLink19URL: https://datasheet.octopart.com:443/C1206C104K5RACTU-Kemet-datasheet-500041.pdf?src-supplier=Farnell; ComponentLink20Description: https://datasheet.octopart.com:443/C1206C104K5RAC-TU-Kemet-datasheet-5315946.pdf?src-supplier=Farnell; ComponentLink20URL: https://datasheet.octopart.com:443/C1206C104K5RAC-TU-Kemet-datasheet-5315946.pdf?src-supplier=Farnell; ComponentLink21Description: https://datasheet.octopart.com:443/C1206C104K5RACTU-KEMET-datasheet-138896532.pdf?src-supplier=Upverter; ComponentLink21URL: https://datasheet.octopart.com:443/C1206C104K5RACTU-KEMET-datasheet-138896532.pdf?src-supplier=Upverter; ComponentLink22Description: https://datasheet.octopart.com:443/C1206C104K5RAC-TU-Kemet-datasheet-5313890.pdf?src-supplier=Newark; ComponentLink22URL: https://datasheet.octopart.com:443/C1206C104K5RAC-TU-Kemet-datasheet-5313890.pdf?src-supplier=Newark; ComponentLink23Description: https://datasheet.octopart.com:443/C1206C104K5RAC-TU-Kemet-datasheet-5390537.pdf?src-supplier=Newark; ComponentLink23URL: https://datasheet.octopart.com:443/C1206C104K5RAC-TU-Kemet-datasheet-5390537.pdf?src-supplier=Newark; ComponentLink24Description: https://datasheet.octopart.com:443/C1206C104K5RAC-TU-Kemet-datasheet-7541751.pdf?src-supplier=Newark; ComponentLink24URL: https://datasheet.octopart.com:443/C1206C104K5RAC-TU-Kemet-datasheet-7541751.pdf?src-supplier=Newark; ComponentLink25Description: https://datasheet.octopart.com:443/C1206C104K5RACTU-KEMET-datasheet-176289761.pdf?src-supplier=Newark; ComponentLink25URL: https://datasheet.octopart.com:443/C1206C104K5RACTU-KEMET-datasheet-176289761.pdf?src-supplier=Newark; ComponentLink26Description: https://datasheet.octopart.com:443/C1206C104K5RACTU-Kemet-datasheet-8764837.pdf?src-supplier=Newark; ComponentLink26URL: https://datasheet.octopart.com:443/C1206C104K5RACTU-Kemet-datasheet-8764837.pdf?src-supplier=Newark; ComponentLink27Description: https://datasheet.octopart.com:443/C1206C104K5RACTU-Kemet-datasheet-8480123.pdf?src-supplier=Newark; ComponentLink27URL: https://datasheet.octopart.com:443/C1206C104K5RACTU-Kemet-datasheet-8480123.pdf?src-supplier=Newark; ComponentLink28Description: https://datasheet.octopart.com:443/C1206C104K5RACTU-Kemet-datasheet-5393970.pdf?src-supplier=Newark; ComponentLink28URL: https://datasheet.octopart.com:443/C1206C104K5RACTU-Kemet-datasheet-5393970.pdf?src-supplier=Newark; ComponentLink29Description: https://datasheet.octopart.com:443/C1206C104K5RACTU-Kemet-datasheet-8502025.pdf?src-supplier=Newark; ComponentLink29URL: https://datasheet.octopart.com:443/C1206C104K5RACTU-Kemet-datasheet-8502025.pdf?src-supplier=Newark; ComponentLink30Description: https://datasheet.octopart.com:443/C1206C104K5RACTU-Kemet-datasheet-161801.pdf?src-supplier=Newark; ComponentLink30URL: https://datasheet.octopart.com:443/C1206C104K5RACTU-Kemet-datasheet-161801.pdf?src-supplier=Newark; ComponentLink31Description: https://datasheet.octopart.com:443/C1206C104K5RACTU-KEMET-datasheet-32036385.pdf?src-supplier=Electro+Sonic; ComponentLink31URL: https://datasheet.octopart.com:443/C1206C104K5RACTU-KEMET-datasheet-32036385.pdf?src-supplier=Electro+Sonic; ComponentLink32Description: https://datasheet.octopart.com:443/C1206C104K5RACTU-Kemet-datasheet-12608295.pdf?src-supplier=element14+APAC; ComponentLink32URL: https://datasheet.octopart.com:443/C1206C104K5RACTU-Kemet-datasheet-12608295.pdf?src-supplier=element14+APAC; ComponentLink33Description: https://datasheet.octopart.com:443/C1206C104K5RACTU-Kemet-datasheet-11992184.pdf?src-supplier=element14+APAC; ComponentLink33URL: https://datasheet.octopart.com:443/C1206C104K5RACTU-Kemet-datasheet-11992184.pdf?src-supplier=element14+APAC; ComponentLink34Description: https://datasheet.octopart.com:443/C1206C104K5RACTU-Kemet-datasheet-10942409.pdf?src-supplier=element14+APAC; ComponentLink34URL: https://datasheet.octopart.com:443/C1206C104K5RACTU-Kemet-datasheet-10942409.pdf?src-supplier=element14+APAC; ComponentLink35Description: https://datasheet.octopart.com:443/C1206C104K5RACTU-Kemet-datasheet-10404442.pdf?src-supplier=element14+APAC; ComponentLink35URL: https://datasheet.octopart.com:443/C1206C104K5RACTU-Kemet-datasheet-10404442.pdf?src-supplier=element14+APAC; ComponentLink36Description: https://datasheet.octopart.com:443/C1206C104K5RACTU-Kemet-datasheet-22084244.pdf?src-supplier=element14+APAC; ComponentLink36URL: https://datasheet.octopart.com:443/C1206C104K5RACTU-Kemet-datasheet-22084244.pdf?src-supplier=element14+APAC; ComponentLink37Description: https://datasheet.octopart.com:443/C1206C104K5RACTU-KEMET-datasheet-164512540.pdf?src-supplier=TME; ComponentLink37URL: https://datasheet.octopart.com:443/C1206C104K5RACTU-KEMET-datasheet-164512540.pdf?src-supplier=TME; ComponentLink38Description: https://datasheet.octopart.com:443/C1206C104K5RACTU-Kemet-datasheet-68096485.pdf?src-supplier=TME; ComponentLink38URL: https://datasheet.octopart.com:443/C1206C104K5RACTU-Kemet-datasheet-68096485.pdf?src-supplier=TME; ComponentLink39Description: https://datasheet.octopart.com:443/C1206C104K5RACTU-KEMET-datasheet-68331906.pdf?src-supplier=TME; ComponentLink39URL: https://datasheet.octopart.com:443/C1206C104K5RACTU-KEMET-datasheet-68331906.pdf?src-supplier=TME; ComponentLink40Description: https://datasheet.octopart.com:443/C1206C104K5RACTU-KEMET-datasheet-110724412.pdf?src-supplier=RS+(Formerly+Allied+Electronics); ComponentLink40URL: https://datasheet.octopart.com:443/C1206C104K5RACTU-KEMET-datasheet-110724412.pdf?src-supplier=RS+(Formerly+Allied+Electronics); ComponentLink41Description: https://datasheet.octopart.com:443/C1206C104K5RACTU-Kemet-datasheet-60243841.pdf?src-supplier=RS+(Formerly+Allied+Electronics); ComponentLink41URL: https://datasheet.octopart.com:443/C1206C104K5RACTU-Kemet-datasheet-60243841.pdf?src-supplier=RS+(Formerly+Allied+Electronics); ComponentLink42Description: https://datasheet.octopart.com:443/C1206C104K5RACTU-Kemet-datasheet-464.pdf?src-supplier=RS+(Formerly+Allied+Electronics); ComponentLink42URL: https://datasheet.octopart.com:443/C1206C104K5RACTU-Kemet-datasheet-464.pdf?src-supplier=RS+(Formerly+Allied+Electronics); ComponentLink43Description: https://datasheet.octopart.com/C1206C104K5RACTU-KEMET-datasheet-84169754.pdf; ComponentLink43URL: https://datasheet.octopart.com/C1206C104K5RACTU-KEMET-datasheet-84169754.pdf; ComponentLink44Description: https://datasheet.octopart.com:443/C1206C104K5RACTU-Kemet-datasheet-13693438.pdf?src-supplier=Factory+Futures; ComponentLink44URL: https://datasheet.octopart.com:443/C1206C104K5RACTU-Kemet-datasheet-13693438.pdf?src-supplier=Factory+Futures; ComponentLink45Description: https://datasheet.octopart.com:443/C1206C104K5RACTU-Kemet-datasheet-11546808.pdf?src-supplier=Arrow+Electronics; ComponentLink45URL: https://datasheet.octopart.com:443/C1206C104K5RACTU-Kemet-datasheet-11546808.pdf?src-supplier=Arrow+Electronics; ComponentLink46Description: https://datasheet.octopart.com:443/C1206C104K5RAC7800-KEMET-datasheet-164857143.pdf?src-supplier=Burklin+Elektronik; ComponentLink46URL: https://datasheet.octopart.com:443/C1206C104K5RAC7800-KEMET-datasheet-164857143.pdf?src-supplier=Burklin+Elektronik; ComponentLink47Description: https://datasheet.octopart.com:443/C1206C104K5RAC-TU-Kemet-datasheet-7273158.pdf?src-supplier=Jameco+(USA); ComponentLink47URL: https://datasheet.octopart.com:443/C1206C104K5RAC-TU-Kemet-datasheet-7273158.pdf?src-supplier=Jameco+(USA); Contact Plating: Tin; Country of Origin: Mexico; Depth: 1.6mm; Dielectric Material: Ceramic; Export Control Classification Number (ECCN) Code: EAR99; Harmonized Tariff Schedule (HTS) Code: 8532.24.00.20; Height: 780µm; Introduction Date: 2008-01-10; Lead Free: Lead Free; Length: 3.2mm; Lifecycle Status: Production; Manufacturer Part Number: C1206C104K5RACTU; Material: Ceramic; Max Operating Temperature: 125°C; Min Operating Temperature: -55°C; Mounting Technology: Surface Mount; Number of Terminals: 2; Packaging: Cut Tape; Pins: 2; Pricing: 1=0.14, 10=0.077, 50=0.0532, 100=0.046, 500=0.0338 (USD); Radiation Hardening: No; REACH SVHC: Unaffected; RoHS: Compliant; Schedule B: 8532240020, 8532240020|8532240020, 8532240020|8532240020|8532240020, 8532240020|8532240020|8532240020|8532240020; Stock: 2241005; Supplier: DigiKey; Supplier Part Number: 399-C1206C104K5RACTUDKR-ND; Termination: SMD/SMT; Voltage Rating: 50V; Voltage Rating (AC): 50V; Voltage Rating (DC): 50V; Width: 1.6mm; Library Name: Tremelo_Pedal.SchLib
D1 = SAC10-E3/54: 500 W 10 V Uni Directional Axial Transient Voltage Suppressor - DO-204AC; Manufacturer: Vishay; Breakdown Voltage: 11.1V; Clamping Voltage: 1V; Element Configuration: Single; Leakage Current: 5µA; Max Power Dissipation: 3W; Max Surge Current: 29A; Number of Channels: 1; Operating Supply Voltage: 10V; Peak Pulse Power: 500W; Test Current: 1mA; Value: 50pF; Case/Package: DO-15; Category: TVS Diodes; China RoHS: Non-Compliant; Component Kind: Standard; ComponentLink1Description: https://datasheet.octopart.com:443/SAC10-E3-54-Vishay-datasheet-181470670.pdf?src-supplier=Newark; ComponentLink1URL: https://datasheet.octopart.com:443/SAC10-E3-54-Vishay-datasheet-181470670.pdf?src-supplier=Newark; ComponentLink2Description: https://datasheet.octopart.com:443/SAC10-E3/54-Vishay-datasheet-11555040.pdf?src-supplier=Newark; ComponentLink2URL: https://datasheet.octopart.com:443/SAC10-E3/54-Vishay-datasheet-11555040.pdf?src-supplier=Newark; ComponentLink3Description: https://datasheet.octopart.com:443/SAC10-E3/54-Vishay-datasheet-8373145.pdf?src-supplier=Newark; ComponentLink3URL: https://datasheet.octopart.com:443/SAC10-E3/54-Vishay-datasheet-8373145.pdf?src-supplier=Newark; ComponentLink4Description: https://datasheet.octopart.com:443/SAC10-E3/54-Vishay-datasheet-5316468.pdf?src-supplier=Newark; ComponentLink4URL: https://datasheet.octopart.com:443/SAC10-E3/54-Vishay-datasheet-5316468.pdf?src-supplier=Newark; ComponentLink5Description: https://datasheet.octopart.com:443/SAC10-E3-54-Vishay-datasheet-78862583.pdf?src-supplier=Newark; ComponentLink5URL: https://datasheet.octopart.com:443/SAC10-E3-54-Vishay-datasheet-78862583.pdf?src-supplier=Newark; ComponentLink6Description: https://datasheet.octopart.com:443/SAC10-E3-54-Vishay-datasheet-33014114.pdf?src-supplier=Newark; ComponentLink6URL: https://datasheet.octopart.com:443/SAC10-E3-54-Vishay-datasheet-33014114.pdf?src-supplier=Newark; ComponentLink7Description: http://sigma.octopart.com:80/rohs_statement/Vishay-company-15.pdf?src-supplier=Compliance+Statements; ComponentLink7URL: http://sigma.octopart.com:80/rohs_statement/Vishay-company-15.pdf?src-supplier=Compliance+Statements; Direction: Unidirectional; Lead Free: Lead Free; Lifecycle Status: Production; Manufacturer Part Number: SAC10-E3/54; Max Operating Temperature: 175°C; Max Reverse Leakage Current: 5µA; Min Breakdown Voltage: 11.1V; Min Operating Temperature: -55°C; Mounting Technology: Through Hole; Number of Elements: 1; Number of Terminals: 2; Peak Pulse Current: 29A; Pins: 2; Polarity: Unidirectional; Power Dissipation: 500W; Pricing: 1=1.96, 10=1.247, 50=0.9376, 100=0.8377, 500=0.66244 (USD); REACH SVHC: Affected; Reverse Breakdown Voltage: 11.1V; Reverse Standoff Voltage: 10V; RoHS: Compliant; Stock: 365; Supplier: DigiKey; Supplier Part Number: 112-SAC10-E3/54CT-ND; Termination: Axial; Library Name: Tremelo_Pedal.SchLib
D6-D9 = WP1503GT: Single Color LED, Green, Transparent, T-1 3/4, 5mm; Manufacturer: Kingbright; Dominant Wavelength: 568nm; Forward Current: 25mA; Forward Voltage: 2.2V; Lens Style: Clear, Dome, Tinted; Luminous Intensity: 60mcd; Number of Circuits: 1; Peak Wavelength: 565nm; Shape: Round; Test Current: 20mA; Viewing Angle: 30°; Case/Package: Radial; Category: LEDs; China RoHS: Compliant; Color: Green; Component Kind: Standard; ComponentLink1Description: https://datasheet.octopart.com:443/WP1503GT-Kingbright-datasheet-180525248.pdf?src-supplier=IHS; ComponentLink1URL: https://datasheet.octopart.com:443/WP1503GT-Kingbright-datasheet-180525248.pdf?src-supplier=IHS; ComponentLink2Description: https://datasheet.octopart.com:443/WP1503GT-Kingbright-datasheet-65593620.pdf?src-supplier=IHS; ComponentLink2URL: https://datasheet.octopart.com:443/WP1503GT-Kingbright-datasheet-65593620.pdf?src-supplier=IHS; ComponentLink3Description: https://datasheet.octopart.com:443/WP1503GT-Kingbright-datasheet-137343189.pdf?src-supplier=Kingbright+SCT; ComponentLink3URL: https://datasheet.octopart.com:443/WP1503GT-Kingbright-datasheet-137343189.pdf?src-supplier=Kingbright+SCT; Country of Origin: Mainland China; Harmonized Tariff Schedule (HTS) Code: 8541.40.20.00; Height: 8.6mm; Illumination Color: Green; Introduction Date: 2005-04-16; Lens Color: Green; Lifecycle Status: Production; Manufacturer Part Number: WP1503GT; Max Operating Temperature: 85°C; Min Operating Temperature: -40°C; Mounting Technology: Through Hole; Number of Terminals: 2; Packaging: Bulk; Pricing: 1=0.24, 10=0.166, 100=0.116, 500=0.09322, 1000=0.08569, 2000=0.07926, 5000=0.0722, 20000=0.06403, 40000=0.06085 (USD); REACH SVHC: Yes; RoHS: Compliant; Stock: 14499; Supplier: DigiKey; Supplier Part Number: WP1503GT-ND; Terminal Pitch: 2.54mm; Wavelength: 568nm; Library Name: EcoCar_Accessory_Controller_V2.IntLib
